# Altium schematic sheet Voltage Regulation.SchDoc
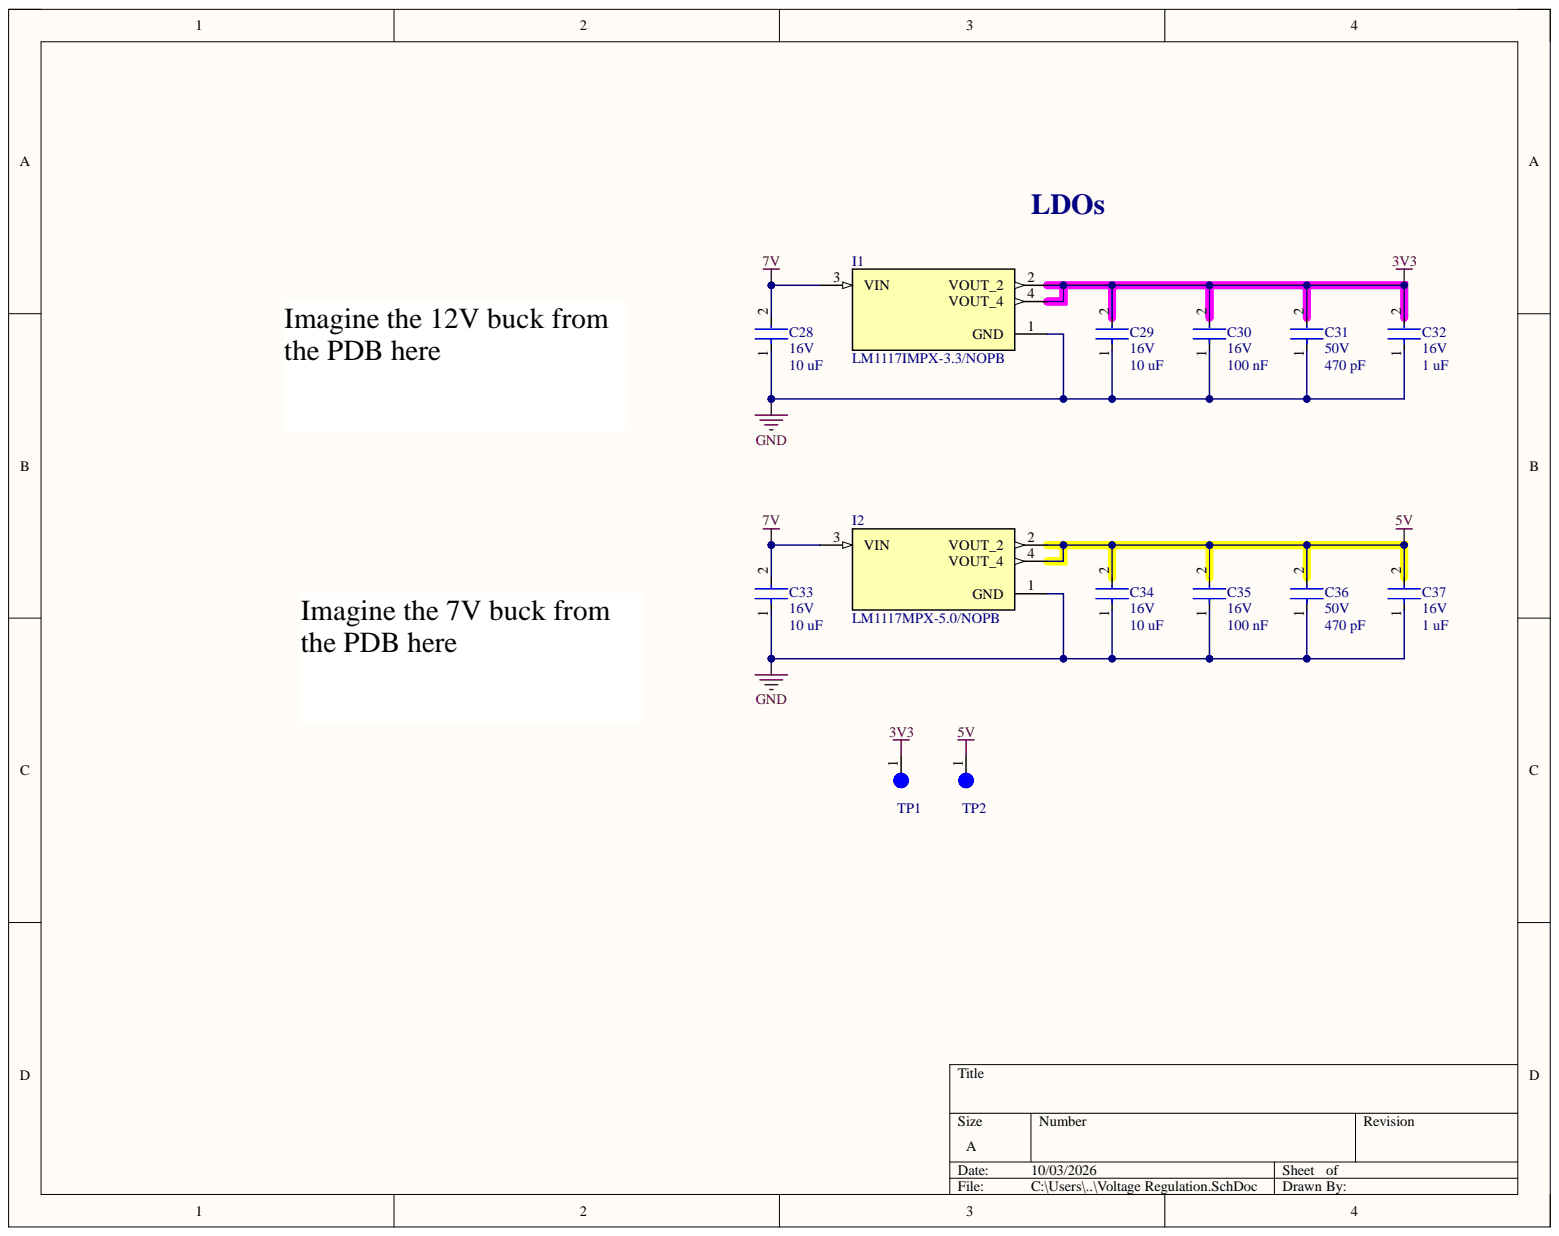
[diagram p0: Voltage Regulation.SchDoc]

## Parts
C28  Voltage Rating: 16V  [CAP-0805]  (hidden comment: CAP 0805)
C29  Capacitance: 10 uF  Voltage Rating: 16V  [CAP-0805]  (hidden comment: CAP 0805)
C30  Voltage Rating: 16V  [CAP-0805]  (hidden comment: CAP 0805)
C31  Voltage Rating: 50V  [CAP-0805]  (hidden comment: CAP 0805)
C32  Capacitance: 1 uF  Voltage Rating: 16V  [CAP-0805]  (hidden comment: CAP 0805)
C33  Voltage Rating: 16V  [CAP-0805]  (hidden comment: CAP 0805)
C34  Capacitance: 10 uF  Voltage Rating: 16V  [CAP-0805]  (hidden comment: CAP 0805)
C35  Voltage Rating: 16V  [CAP-0805]  (hidden comment: CAP 0805)
C36  Voltage Rating: 50V  [CAP-0805]  (hidden comment: CAP 0805)
C37  Capacitance: 1 uF  Voltage Rating: 16V  [CAP-0805]  (hidden comment: CAP 0805)
I1  LM1117IMPX-3.3/NOPB  [IC-SOT-223-4]
I2  LM1117MPX-5.0/NOPB  [IC-SOT-223-4]
TP1  5003  [TEST-POINT-0001]  (value not drawn)
TP2  5003  [TEST-POINT-0001]  (value not drawn)

## Nets
3V3: C29.2 C30.2 C31.2 C32.2 I1.2(VOUT_2) I1.4(VOUT_4) TP1.1
5V: C34.2 C35.2 C36.2 C37.2 I2.2(VOUT_2) I2.4(VOUT_4) TP2.1
7V: C28.2 C33.2 I1.3(VIN) I2.3(VIN)
GND: C28.1 C29.1 C30.1 C31.1 C32.1 C33.1 C34.1 C35.1 C36.1 C37.1 I1.1(GND) I2.1(GND)

## Library data of the parts
C28 C33 = CAP 0805: Capacitance: 10 uF; Component Kind: Standard; Library Name: EHW_27.IntLib
C30 C35 = CAP 0805: Capacitance: 100 nF; Component Kind: Standard; Library Name: EHW_27.IntLib
C31 C36 = CAP 0805: Capacitance: 470 pF; Component Kind: Standard; Library Name: EHW_27.IntLib
I1 = IC_LDO_3V3_0001: IC REG LIN 3.3V 800MA SOT223-4; Component Kind: Standard; ComponentLink1Description: New link; ComponentLink1URL: https://www.ti.com/lit/ds/symlink/lm1117.pdf?HQS=dis-dk-null-digikeymode-dsf-pf-null-wwe&ts=1721700739746; Library Name: EHW_27.IntLib
I2 = IC_LDO_5V_0001: IC REG LINEAR 5V 800MA SOT223-4; Component Kind: Standard; ComponentLink1Description: Datasheet; ComponentLink1URL: https://www.ti.com/lit/ds/symlink/lm1117.pdf?HQS=dis-dk-null-digikeymode-dsf-pf-null-wwe&ts=1721700739746; Library Name: EHW_27.IntLib
TP1 TP2 = TEST-POINT-0001: Oranange PC Test Point, Miniature Phoisphor Bronze Silver Plating Through Hole Mounting Type; Component Kind: Standard; Library Name: EHW_27.IntLib
C29 C32 C34 C37 = CAP 0805: Component Kind: Standard; Library Name: EHW_27.IntLib
